# Altium schematic sheet 01-Antennas.SchDoc
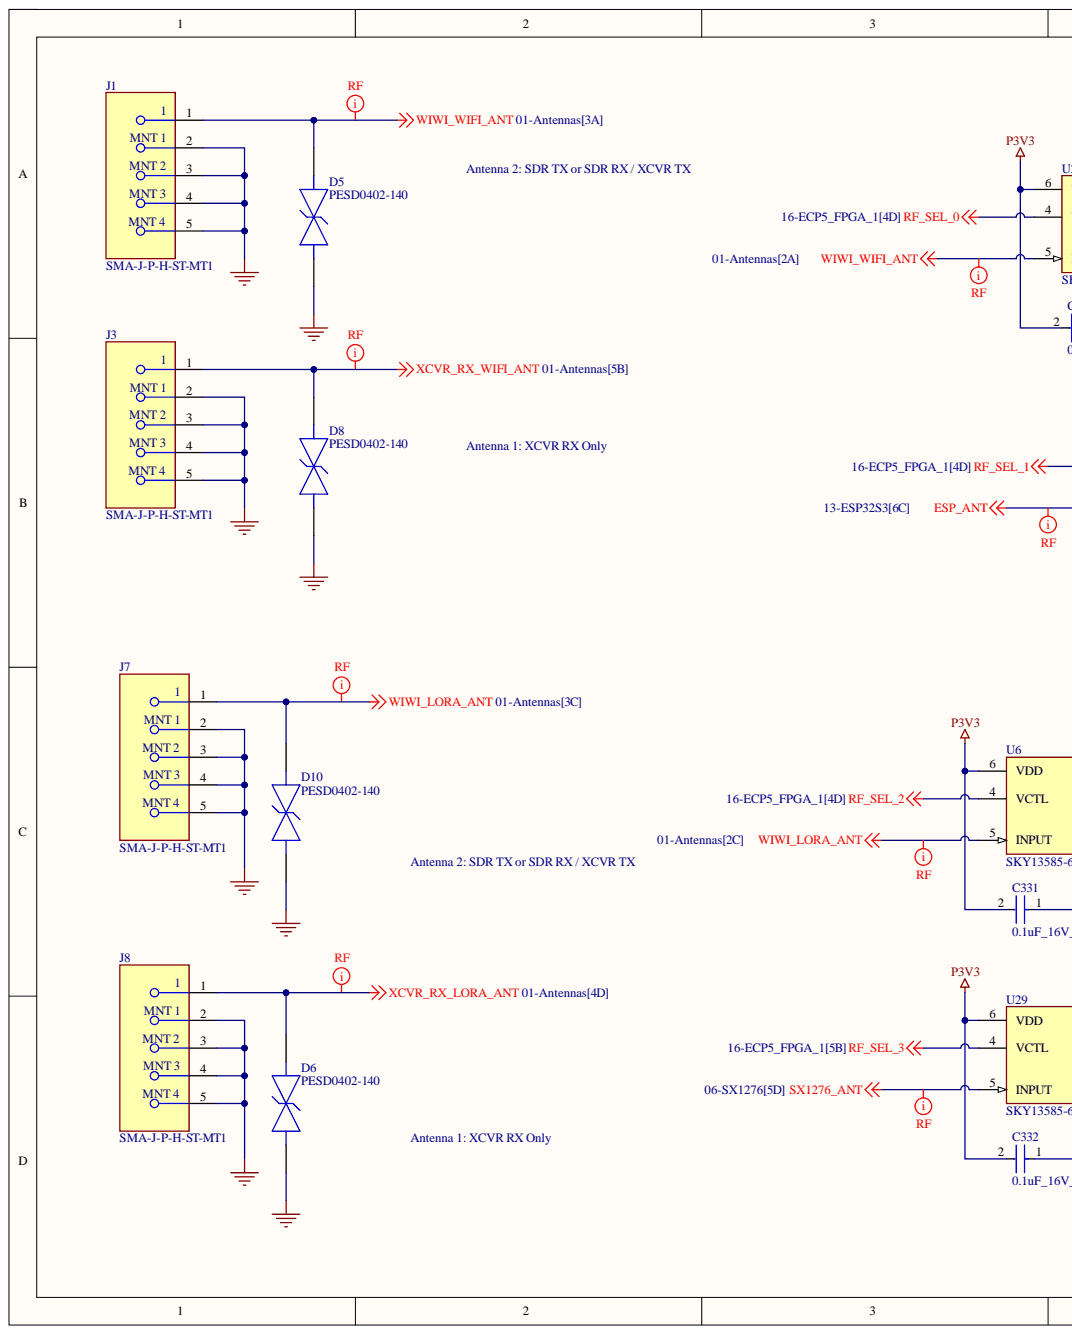
[diagram p0: 01-Antennas.SchDoc, left]
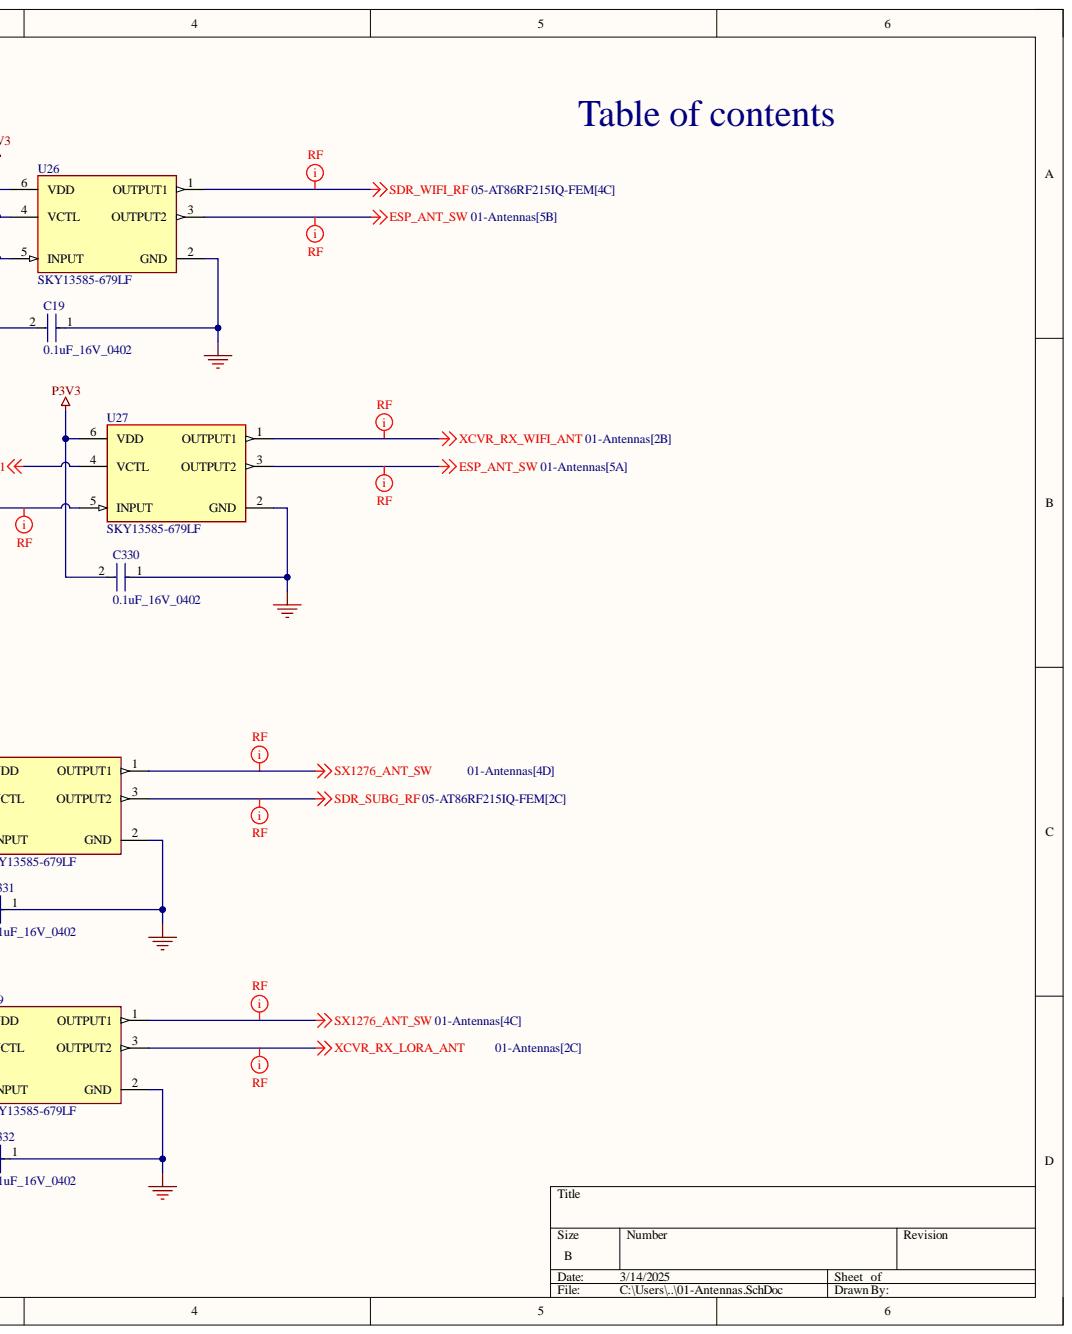
[diagram p1: 01-Antennas.SchDoc, right]

## Parts
C19  0.1uF_16V_0402  [FP-C0402-BB-MFG]
C330  0.1uF_16V_0402  [FP-C0402-BB-MFG]
C331  0.1uF_16V_0402  [FP-C0402-BB-MFG]
C332  0.1uF_16V_0402  [FP-C0402-BB-MFG]
D5  PESD0402-140  [FP-PESD0402-MFG]
D6  PESD0402-140  [FP-PESD0402-MFG]
D8  PESD0402-140  [FP-PESD0402-MFG]
D10  PESD0402-140  [FP-PESD0402-MFG]
J1  SMA-J-P-H-ST-MT1  [FP-SMA-J-P-H-ST-MT1-MFG]
J3  SMA-J-P-H-ST-MT1  [FP-SMA-J-P-H-ST-MT1-MFG]
J7  SMA-J-P-H-ST-MT1  [FP-SMA-J-P-H-ST-MT1-MFG]
J8  SMA-J-P-H-ST-MT1  [FP-SMA-J-P-H-ST-MT1-MFG]
U6  SKY13585-679LF  [FP-SKY13585-679LF-MFG]
U26  SKY13585-679LF  [FP-SKY13585-679LF-MFG]
U27  SKY13585-679LF  [FP-SKY13585-679LF-MFG]
U29  SKY13585-679LF  [FP-SKY13585-679LF-MFG]

## Nets
ESP_ANT: U27.5(INPUT)
ESP_ANT_SW: U26.3(OUTPUT2) U27.3(OUTPUT2)
GND: C19.1 C330.1 C331.1 C332.1 D5.1 D6.1 D8.1 D10.1 J1.2 J1.3 J1.4 J1.5 J3.2 J3.3 J3.4 J3.5 J7.2 J7.3 J7.4 J7.5 J8.2 J8.3 J8.4 J8.5 U6.2(GND) U26.2(GND) U27.2(GND) U29.2(GND)
P3V3: C19.2 C330.2 C331.2 C332.2 U6.6(VDD) U26.6(VDD) U27.6(VDD) U29.6(VDD)
RF_SEL_0: U26.4(VCTL)
RF_SEL_1: U27.4(VCTL)
RF_SEL_2: U6.4(VCTL)
RF_SEL_3: U29.4(VCTL)
SDR_SUBG_RF: U6.3(OUTPUT2)
SDR_WIFI_RF: U26.1(OUTPUT1)
SX1276_ANT: U29.5(INPUT)
SX1276_ANT_SW: U6.1(OUTPUT1) U29.1(OUTPUT1)
WIWI_LORA_ANT: D10.2 J7.1 U6.5(INPUT)
WIWI_WIFI_ANT: D5.2 J1.1 U26.5(INPUT)
XCVR_RX_LORA_ANT: D6.2 J8.1 U29.3(OUTPUT2)
XCVR_RX_WIFI_ANT: D8.2 J3.1 U27.1(OUTPUT1)

## Library data of the parts
C19 C330-C332 = CMP-1034-02068-3: CAP CER 0.1UF 16V 5% X7R 0402; Manufacturer: Kemet; Manufacturer Part Number: C0402C104J4RACTU; Capacitance: 0.10 μF; Material: Ceramic; Temperature Coefficient: 15%; Tolerance: 0.05; Value: 0.10 μF; Automotive: No; Category: Cap; Component Kind: Standard; ComponentLink2Description: Datasheet; ComponentLink2URL: https://content.kemet.com/datasheets/KEM_C1002_X7R_SMD.pdf; Device Class L1: Passive Components; Device Class L2: Capacitors; Device Class L3: Ceramic Capacitors; Digikey Description: CAP CER 0.1UF 16V 5% X7R 0402; Height: 0.55mm; Ipc Land Pattern Name: CAPC10050X50; Lead Free: Yes; Library Name: Altium Content Vault; Mouser Description: CAP CER 0.1UF 16V X7R 0402; Octopart: https://octopart.com/c0402c104j4ractu-kemet-12573893; Package: C0402-BB; RoHS: TRUE; Temperature: -55°C to +125°C; Temperature Characteristic: X7R; Temperature Range High: +125°C; Temperature Range Low: -55°C; Voltage Rating: 16 V
D5 D6 D8 D10 = CMP-08607-000011-1: TVS DIODE 14V 40V 0402; Manufacturer: Littelfuse Inc.; Automotive Grade: Grade 1; Capacitance: 0.25pF; Direction: Bidirectional; Number Of Channels: 1; Value: 0.25pF; Automotive: Yes; Breakdown Voltage: 14V; Category: Diode; Clamping Voltage: 40V; Component Kind: Standard; ComponentLink2Description: Datasheet; ComponentLink2URL: https://www.littelfuse.com/~/media/electronics/datasheets/polymer_esd_suppressors/littelfuse_polymer_esd_suppressor_pesd_catalog_datasheet.pdf.pdf; Device Class L1: Circuit Protection; Device Class L2: TVS Diodes; Device Class L3: unset; Digikey Description: TVS DIODE 14V 40V 0402; Height: 0.43mm; Ipc Land Pattern Name: DIOC10050X33; Lead Free: Yes; Library Name: Altium Content Vault; Manufacturer Part Number: PESD0402-140; Octopart: https://octopart.com/pesd0402-140-littelfuse-74215061; Package: PESD0402; Reverse Standoff Voltage: 14V; RoHS: TRUE; Temperature: -55°C to +125°C; Temperature Range High: +125°C; Temperature Range Low: -55°C
J1 J3 J7 J8 = CMP-02754-000007-1: CONN SMA JACK STR 50 OHM SMD; Body Material: Brass; Body Plating: Gold; Dielectric Material: PTFE; Impedance: 50R; Max Frequency: 20GHz; Number of Ports: 1; Voltage Rating: 335V; Case/Package: SMA; Center Contact Plating: Gold; Component Kind: Standard; Connector Type: SMA; Contact Material: Copper Alloy; Contact Plating: Gold; Fastening Type: Threaded; Housing Color: Gold; Library Name: Altium Content Vault; Max Operating Temperature: 125°C; Min Operating Temperature: -65°C; Mount: Surface Mount; Packaging: Bulk; RoHS Compliant: No; Series: -; Termination: Solder
U6 U26 U27 U29 = CMP-85923-000009-1: IC RF SWITCH SPDT 6GHZ 6MLPD; IIP3: 56dBm; Impedance: 50R; Insertion Loss: 0.5dB; Test Frequency: 2.5GHz; Component Kind: Standard; Library Name: Altium Content Vault; Max Operating Temperature: 90°C; Min Operating Temperature: -40°C; Packaging: Cut Tape; RoHS Compliant: Yes; Series: -; Throw Configuration: SPDT
